# Altium schematic sheet ICESugar.SchDoc
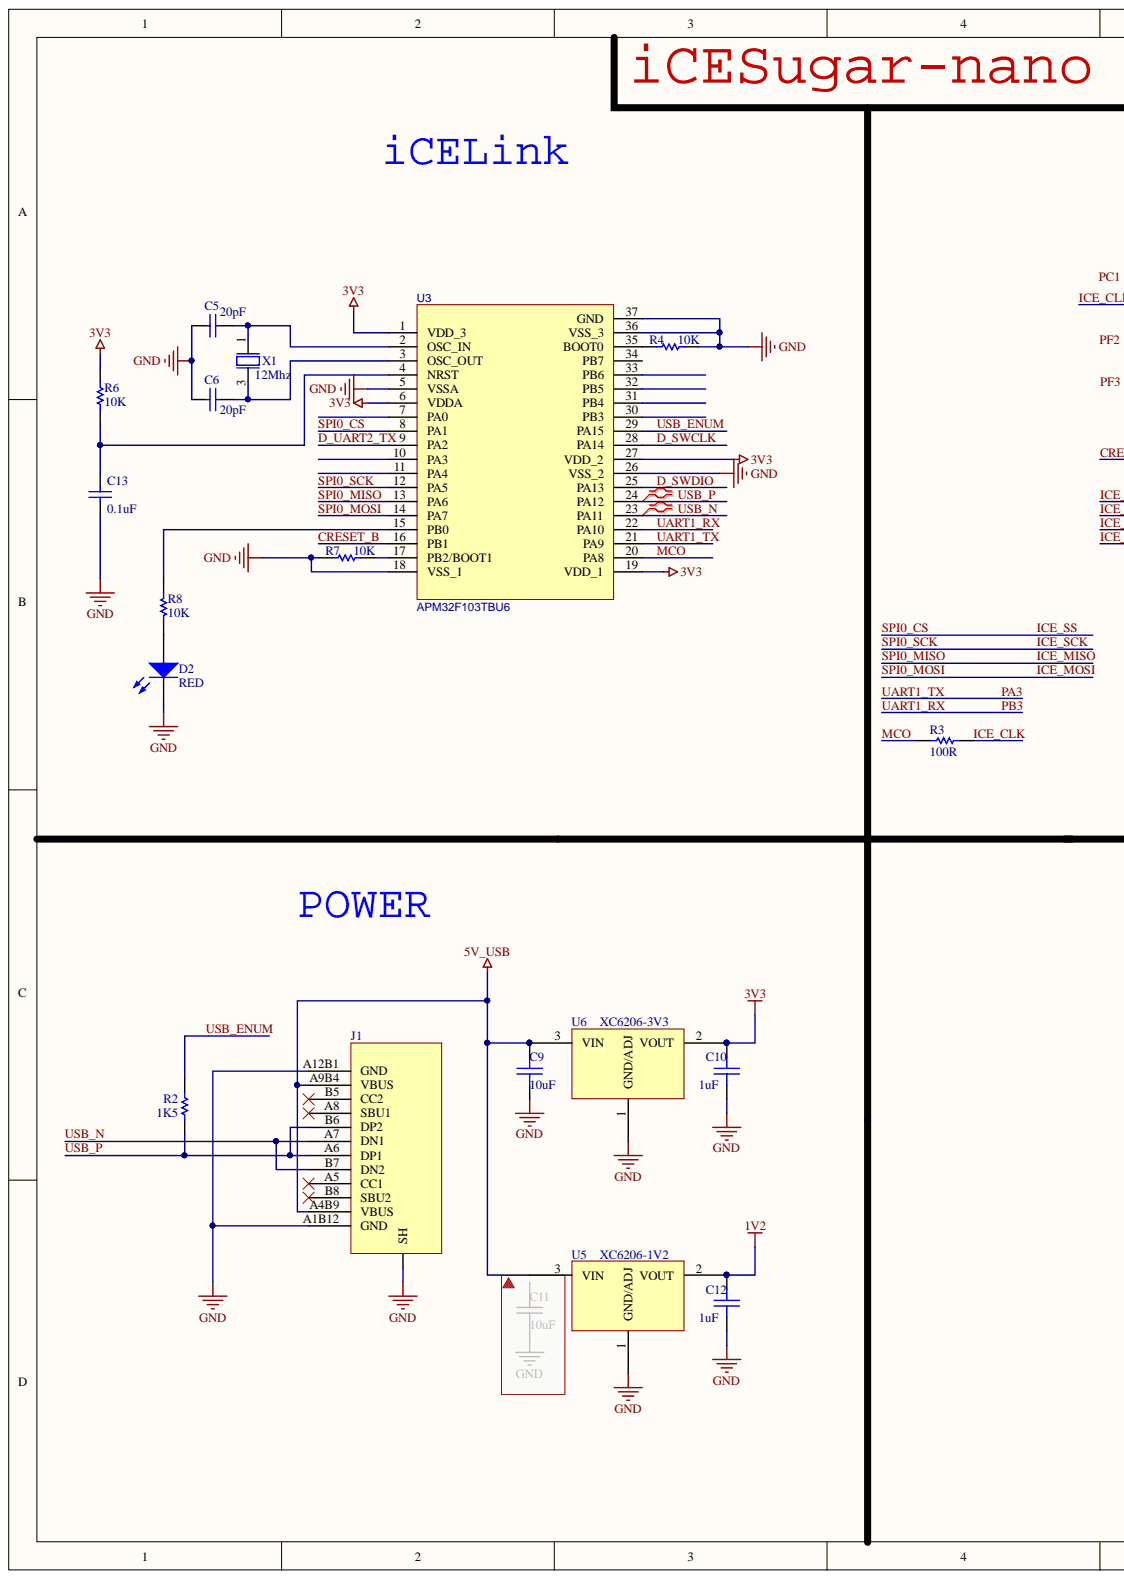
[diagram p0: ICESugar.SchDoc, left]
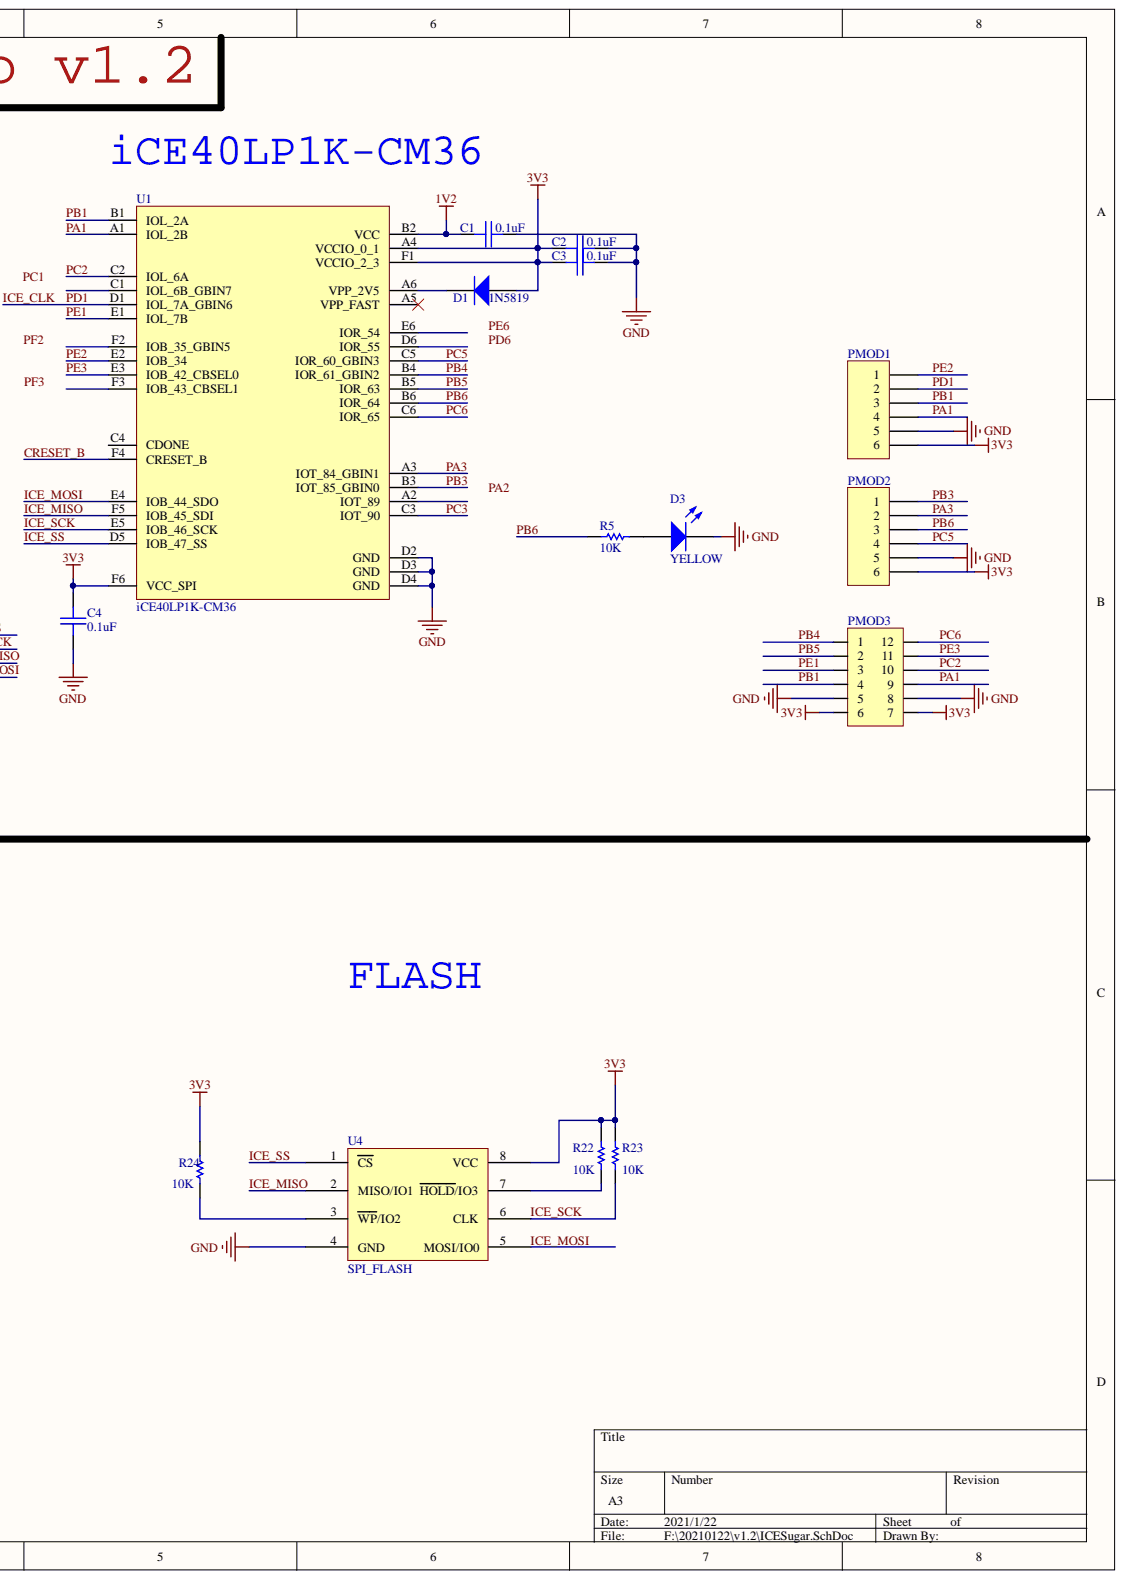
[diagram p1: ICESugar.SchDoc, right]

## Parts
C1  0.1uF  [C 0402_M]
C2  0.1uF  [C 0402_M]
C3  0.1uF  [C 0402_M]
C4  0.1uF  [C 0402_M]
C5  value 20pF  [C 0402_M]  (hidden comment: C0603)
C6  value 20pF  [C 0402_M]  (hidden comment: C0603)
C9  10uF  [C 0402_M]
C10  1uF  [C 0402_M]
C12  1uF  [C 0402_M]
C13  value 0.1uF  [C 0402_M]  (hidden comment: C0603)
D1  1N5819  [SOD-323]
D2  RED  [0603_LED_R]
D3  YELLOW  [0603_LED_B]
J1  Type_C_16P  [Type_C_16P]  (value not drawn)
PMOD1  Header 6x1  [HEADER_6x1]  (value not drawn)
PMOD2  Header 6x1  [HEADER_6x1]  (value not drawn)
PMOD3  Header 4  [HEADER_2x6]  (value not drawn)
R2  value 1K5  [R 0402_M]  (hidden comment: R0603)
R3  value 100R  [R 0402_M]  (hidden comment: R0603)
R4  value 10K  [R 0402_M]  (hidden comment: R0603)
R5  value 10K  [R 0402_M]  (hidden comment: R0603)
R6  value 10K  [R 0402_M]  (hidden comment: R0603)
R7  value 10K  [R 0402_M]  (hidden comment: R0603)
R8  value 10K  [R 0402_M]  (hidden comment: R0603)
R22  value 10K  [R 0402_M]  (hidden comment: R0603)
R23  value 10K  [R 0402_M]  (hidden comment: R0603)
R24  value 10K  [R 0402_M]  (hidden comment: R0603)
U1  iCE40LP1K-CM36  [*BGA36N40P6X6_243X268X69N-costdown]
U3  APM32F103TBU6  [*QFN50P600X600X100-37N]
U4  SPI_FLASH  [WSON-8_L6.0-W5.0-P1.27-BL-EP]
U5  XC6206-1V2  [SOT23-3M]
U6  XC6206-3V3  [SOT23-3M]
X1  12Mhz  [BT-XTAL_3225]

## Nets
1V2: C1.1 C12.1 U1.B2(VCC) U5.2
3V3: C2.1 C3.1 C4.2 C10.1 D1.1 PMOD1.6 PMOD2.6 PMOD3.6 PMOD3.7 R6.1 R22.1 R23.1 R24.1 U1.A4(VCCIO_0_1) U1.F1(VCCIO_2_3) U1.F6(VCC_SPI) U3.1(VDD_3) U3.6(VDDA) U3.19(VDD_1) U3.27(VDD_2) U4.8(VCC) U6.2
5V_USB: C9.1 J1.A4B9(VBUS) J1.A9B4(VBUS) U5.3 U6.3
GND: C1.2 C2.2 C3.2 C4.1 C5.2 C6.2 C9.2 C10.2 C12.2 C13.1 D2.2 D3.2 J1.0(SH) J1.A1B12(GND) J1.A12B1(GND) PMOD1.5 PMOD2.5 PMOD3.5 PMOD3.8 R4.2 R7.1 U1.D2(GND) U1.D3(GND) U1.D4(GND) U3.5(VSSA) U3.18(VSS_1) U3.26(VSS_2) U3.36(VSS_3) U3.37(GND) U4.4(GND) U5.1 U6.1 X1.2 X1.4
CRESET_B: U1.F4(CRESET_B) U3.16(PB1)
D_SWCLK: U3.28(PA14)
D_SWDIO: U3.25(PA13)
D_UART2_TX: U3.9(PA2)
ICE_CLK: PMOD1.2 R3.2 U1.D1(IOL_7A_GBIN6)
ICE_MISO: U1.F5(IOB_45_SDI) U3.13(PA6) U4.2(MISO/IO1)
ICE_MOSI: U1.E4(IOB_44_SDO) U3.14(PA7) U4.5(MOSI/IO0)
ICE_SCK: R23.2 U1.E5(IOB_46_SCK) U3.12(PA5) U4.6(CLK)
ICE_SS: U1.D5(IOB_47_SS) U3.8(PA1) U4.1(CS)
MCO: R3.1 U3.20(PA8)
PA1: PMOD1.4 PMOD3.9 U1.A1(IOL_2B)
PA3: PMOD2.2 U1.A3(IOT_84_GBIN1) U3.21(PA9)
PB1: PMOD1.3 PMOD3.4 U1.B1(IOL_2A)
PB3: PMOD2.1 U1.B3(IOT_85_GBIN0) U3.22(PA10)
PB4: PMOD3.1 U1.B4(IOR_61_GBIN2)
PB5: PMOD3.2 U1.B5(IOR_63)
PB6: PMOD2.3 R5.2 U1.B6(IOR_64)
PC2: PMOD3.10 U1.C2(IOL_6A)
PC3: U1.C3(IOT_90)
PC5: PMOD2.4 U1.C5(IOR_60_GBIN3)
PC6: PMOD3.12 U1.C6(IOR_65)
PE1: PMOD3.3 U1.E1(IOL_7B)
PE2: PMOD1.1 U1.E2(IOB_34)
PE3: PMOD3.11 U1.E3(IOB_42_CBSEL0)
USB_ENUM: R2.2 U3.29(PA15)
USB_N: J1.A7(DN1) J1.B7(DN2) U3.23(PA11)
USB_P: J1.A6(DP1) J1.B6(DP2) R2.1 U3.24(PA12)
NetC5_1: C5.1 U3.2(OSC_IN) X1.1
NetC6_1: C6.1 U3.3(OSC_OUT) X1.3
NetC13_2: C13.2 R6.2 U3.4(NRST)
NetD1_2: D1.2 U1.A6(VPP_2V5)
NetD2_1: D2.1 R8.1
NetD3_1: D3.1 R5.1
NetR4_1: R4.1 U3.35(BOOT0)
NetR7_2: R7.2 U3.17(PB2/BOOT1)
NetR8_2: R8.2 U3.15(PB0)
NetR22_2: R22.2 U4.7(HOLD/IO3)
NetR24_2: R24.2 U4.3(WP/IO2)
PA2:
PC1:
PD6:
PE6:
PF2:
PF3:

Not connected: J1 A5(CC1) A8(SBU1) B5(CC2) B8(SBU2); U1 A2(IOT_89) A5(VPP_FAST) C1(IOL_6B_GBIN7) C4(CDONE) D6(IOR_55) E6(IOR_54) F2(IOB_35_GBIN5) F3(IOB_43_CBSEL1); U3 7(PA0) 10(PA3) 11(PA4) 30(PB3) 31(PB4) 32(PB5) 33(PB6) 34(PB7)

## Library data of the parts
C1-C4 C10 C12 = SMT_C_0402: Surface mount capacitor 0402; Component Kind: Standard; Library Name: Vowstar_Basic_Device.IntLib
C5 C6 C13 = C0603: Capacitor; Component Kind: Standard; LatestRevisionDate: 17-Jul-2002; LatestRevisionNote: Re-released for DXP Platform.; Library Name: MYLIB.IntLib; PackageDescription: Radial Cap, Thru-Hole; 2 Leads; 0.3 in Pin Spacing; PackageReference: RAD-0.3; Published: 8-Jun-2000; Signal Integrity: Cap; Simulation: CAP; Publisher: Altium Limited
C9 = SMT_C_0805: Surface mount capacitor 0805; Component Kind: Standard; Library Name: Vowstar_Basic_Device.IntLib
D1 = Diode: Default Diode; Code_JEDEC: DO-214-AB; Component Kind: Standard; LatestRevisionDate: 17-Jul-2002; LatestRevisionNote: Re-released for DXP Platform.; Library Name: Miscellaneous Devices.IntLib; PackageDescription: DO-214-AB/SMC; 2 C-Bend Leads; Body 7.9 x 5.9 mm, inc. leads (LxW); PackageReference: SMC; PackageVersion: Sep-1996; Published: 8-Jun-2000; Signal Integrity: Diode; Simulation: DIODE; Publisher: Altium Limited
D2 D3 = D0603: Typical RED, GREEN, YELLOW, AMBER GaAs LED; Component Kind: Standard; LatestRevisionDate: 17-Jul-2002; LatestRevisionNote: Re-released for DXP Platform.; Library Name: MYLIB.IntLib; PackageDescription: SM LED; 2 Flat Leads; PackageReference: 3.2X1.6X1.1; PackageVersion: Feb-2002; Published: 8-Jun-2000; Simulation: LED2; Publisher: Altium Limited
PMOD3 = Header 2x6: Header, 4-Pin; Component Kind: Standard; LatestRevisionDate: 17-Jul-2002; LatestRevisionNote: Re-released for DXP Platform.; Signal Integrity: Connector; Library Name: ICESugar.SCHLIB; Publisher: Altium Limited
R2-R8 R22-R24 = R0603: Resistor; Component Kind: Standard; LatestRevisionDate: 17-Jul-2002; LatestRevisionNote: Re-released for DXP Platform.; Library Name: MYLIB.IntLib; PackageDescription: Axial Device, Thru-Hole; 2 Leads; 0.3 in Pin Spacing; PackageReference: AXIAL-0.3; Published: 8-Jun-2000; Signal Integrity: Res; Simulation: RESISTOR; Publisher: Altium Limited
U1 = iCE40LP1k: Component Kind: Standard; Library Name: ICESugar.SCHLIB
U3 = STM32F103T8U6: BOM_Manufacturer: STMicroelectronics; BOM_Manufacturer Part: STM32F103T8U6; BOM_Supplier: LCSC; BOM_Supplier Part: C19671; Component Kind: Standard; image: //image.lceda.cn/szlcsc/C19671.jpg; Library Name: teensy.SCHLIB; spicePre: U
U5 U6 = NCP1117: NCP1117, NCV1117. 1.0 A Low-Dropout Positive  Fixed and Adjustable  Voltage Regulators; Component Kind: Standard; Library Name: Vowstar_IC_General.IntLib
X1 = XTAL SMD 12MHz (3225): XTAL SMD 3225, 8MHz, 18pF, ±30ppm; 2nd source part number: 184-2056; Manufacturer: TXC Corporation; Manufacturer Part Number: 7M-12.000MAAJ-T; Artikkelnr Noca: 22019166; Artikkelnr Simpro: N.A.; Database Table Name: Crystal_Osc$; Note: XTAL SMD 12.000MHz; 2nd source Supplier: Farnell; Component Kind: Standard; Datasheet: http://www.txccrystal.com/images/pdf/7m.pdf; Footprint Doc: BT-XTAL_3225; LatestRevisionDate: 2010-08-31; Library Name: Nordic Discrete DB.DbLib; Part Number: XTAL SMD 12MHz (3225); Pin Count: 4; Published: 2010-08-31; Supplier: Digi-Key; Supplier part number: 887-1121-1-ND; Value: 12Mhz; Publisher: Nordic Semiconductor
J1 = Type_C_16P: Component Kind: Standard; Library Name: ICESugar.SCHLIB
PMOD1 PMOD2 = Header 6x1: Component Kind: Standard; Library Name: ICESugar.SCHLIB
U4 = SPI_FLASH: Component Kind: Standard; Library Name: ICESugar-Pro.SCHLIB
